# Altium schematic sheet Esquematico_proyecto.SchDoc
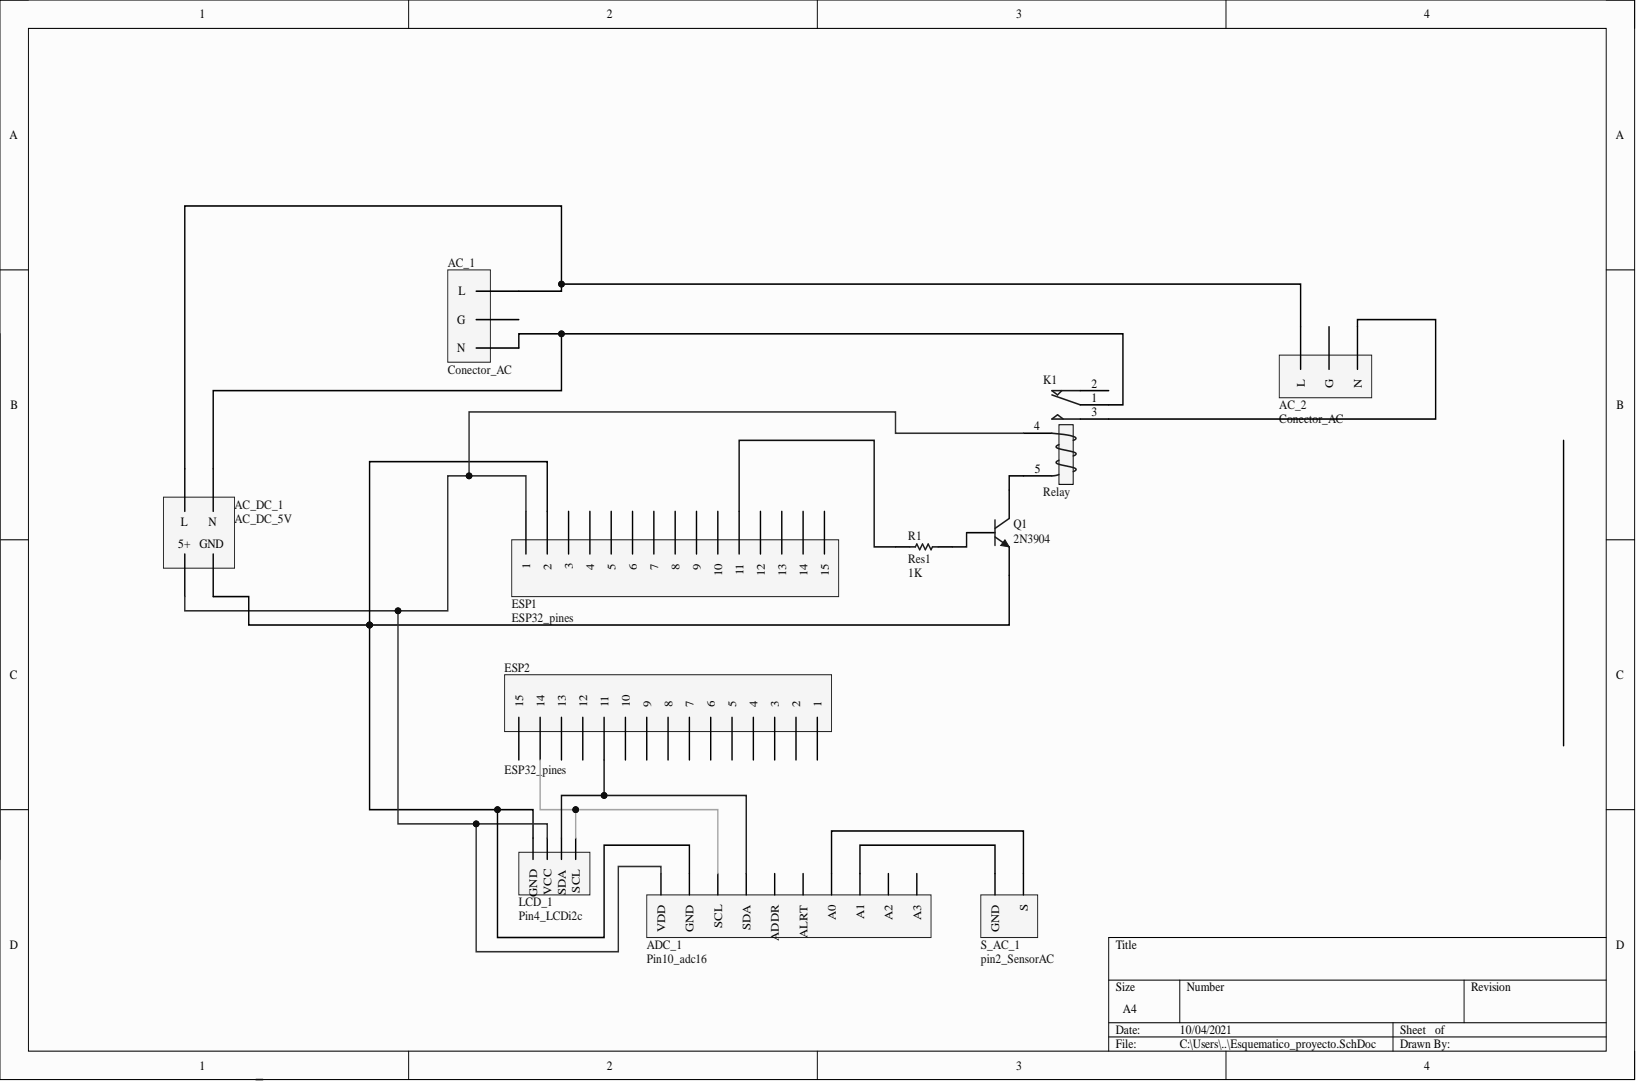
[diagram p0: Esquematico_proyecto.SchDoc]

## Parts
AC_1  Conector_AC  [conectorAC_PCB]
AC_2  Conector_AC  [conectorAC_PCB]
AC_DC_1  AC_DC_5V  [AC_DC_5v]
ADC_1  Pin10_adc16  [PinADC_10]
ESP1  ESP32_pines  [ESP32]
ESP2  ESP32_pines  [ESP32]
K1  Relay  [MODULE5B]
LCD_1  Pin4_LCDi2c  [Pin4_LCDPCB]
Q1  2N3904  [TO-92A]
R1  Res1  value 1K  [AXIAL-0.3]
S_AC_1  pin2_SensorAC  [Pin2_SensorAC]

## Nets
NetAC_1_1: AC_1.1 AC_2.1 AC_DC_1.1(L)
NetAC_1_3: AC_1.3 AC_DC_1.2(N) K1.1
NetAC_2_3: AC_2.3 K1.3
NetAC_DC_1_3: AC_DC_1.3(5+) ADC_1.1(VDD) ESP1.1 K1.4 LCD_1.2(VCC)
NetAC_DC_1_4: AC_DC_1.4 ADC_1.2(GND) ESP1.2 LCD_1.1(GND) Q1.1
NetADC_1_3: ADC_1.3(SCL) ESP2.14 LCD_1.4(SCL)
NetADC_1_4: ADC_1.4(SDA) ESP2.11 LCD_1.3(SDA)
NetADC_1_7: ADC_1.7(A0) S_AC_1.2
NetADC_1_8: ADC_1.8(A1) S_AC_1.1
NetESP1_11: ESP1.11 R1.1
NetK1_5: K1.5 Q1.3
NetQ1_2: Q1.2 R1.2

Not connected: AC_1 2; AC_2 2; ADC_1 5 6(ALRT) 9(A2) 10(A3); ESP1 3 4 5 6 7 8 9 10 13 14 15; ESP2 1 2 3 4 5 6 7 8 9 10 13 15; K1 2

## Library data of the parts
K1 = Relay: SPDT Relay; Component Kind: Standard; LatestRevisionDate: 17-Jul-2002; LatestRevisionNote: Re-released for DXP Platform.; Library Name: Miscellaneous Devices.IntLib; PackageReference: MODULE5B; Published: 8-Jun-2000; Simulation: SPDTRELAY; Publisher: Altium Limited
Q1 = 2N3904: NPN General Purpose Amplifier; Component Kind: Standard; ComponentLink1Description: Manufacturer Link; ComponentLink1URL: http://www.fairchildsemi.com/; ComponentLink2Description: Datasheet; ComponentLink2URL: http://www.fairchildsemi.com/ds/2N%2F2N3904.pdf; DatasheetVersion: 1997; LatestRevisionDate: 15-Jan-2003; LatestRevisionNote: PCB Footprint 'BCY-W3/D4.7' replaced; Library Name: Miscellaneous Devices.IntLib; PackageDescription: TO, Flat Index; 3 In-Line, Axial Leads; Body Dia. 4.5mm; Leads 0.51 x 0.48 mm (max); PackageReference: TO-92A; PackageVersion: Sep-1998; Published: 8-Jun-2000; Signal Integrity: 2N3904; Simulation: 2N3904; Publisher: Altium Limited
R1 = Res1: Resistor; Component Kind: Standard; LatestRevisionDate: 17-Jul-2002; LatestRevisionNote: Re-released for DXP Platform.; Library Name: Miscellaneous Devices.IntLib; PackageDescription: Axial Device, Thru-Hole; 2 Leads; 0.3 in Pin Spacing; PackageReference: AXIAL-0.3; Published: 8-Jun-2000; Signal Integrity: Res; Simulation: RESISTOR; Publisher: Altium Limited
AC_1 AC_2 = Conector_AC: Component Kind: Standard; Library Name: Libreria_Esquemas.SchLib
AC_DC_1 = AC_DC_5V: Component Kind: Standard; Library Name: Libreria_Esquemas.SchLib
ADC_1 = Pin10_adc16: Component Kind: Standard; Library Name: Libreria_Esquemas.SchLib
ESP1 ESP2 = ESP32_pines: Component Kind: Standard; Library Name: Pin_out_20.SchLib
LCD_1 = Pin4_LCDi2c: Component Kind: Standard; Library Name: Pin_out_20.SchLib
S_AC_1 = pin2_SensorAC: Component Kind: Standard; Library Name: Pin_out_20.SchLib
